# Altium schematic sheet PwrLMS7002M.SchDoc(PwrLMS7002M)
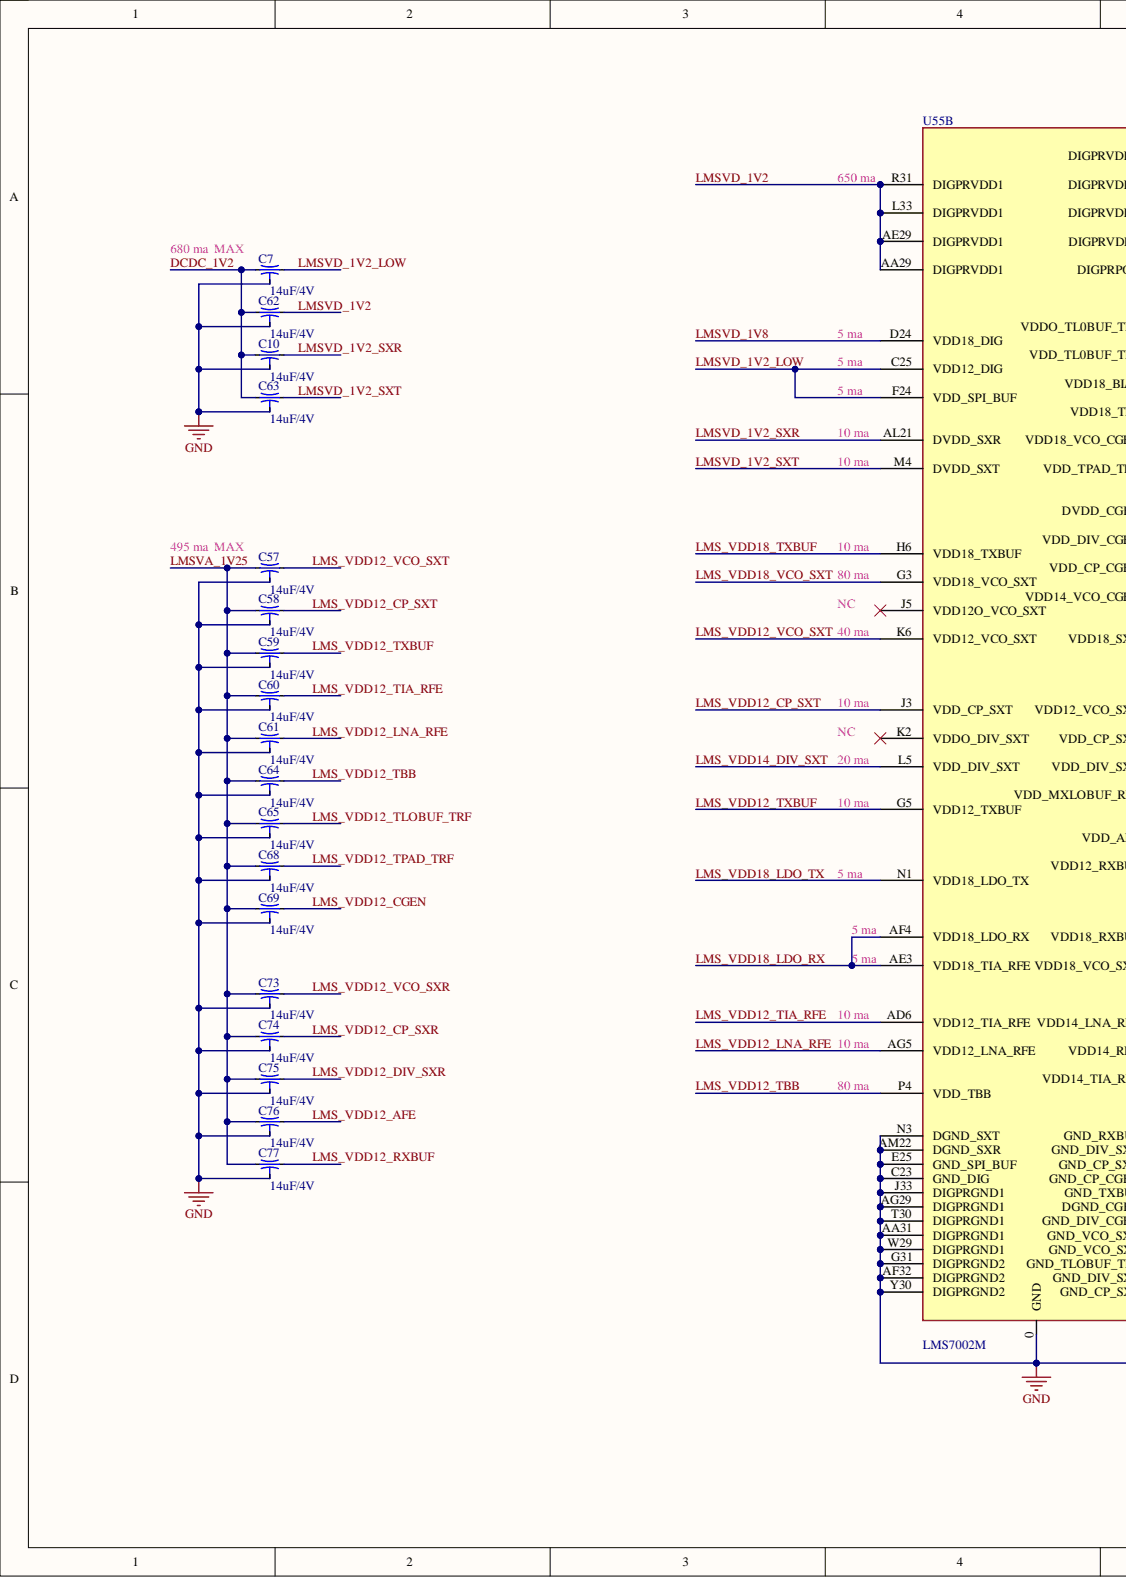
[diagram p0: PwrLMS7002M.SchDoc, left]
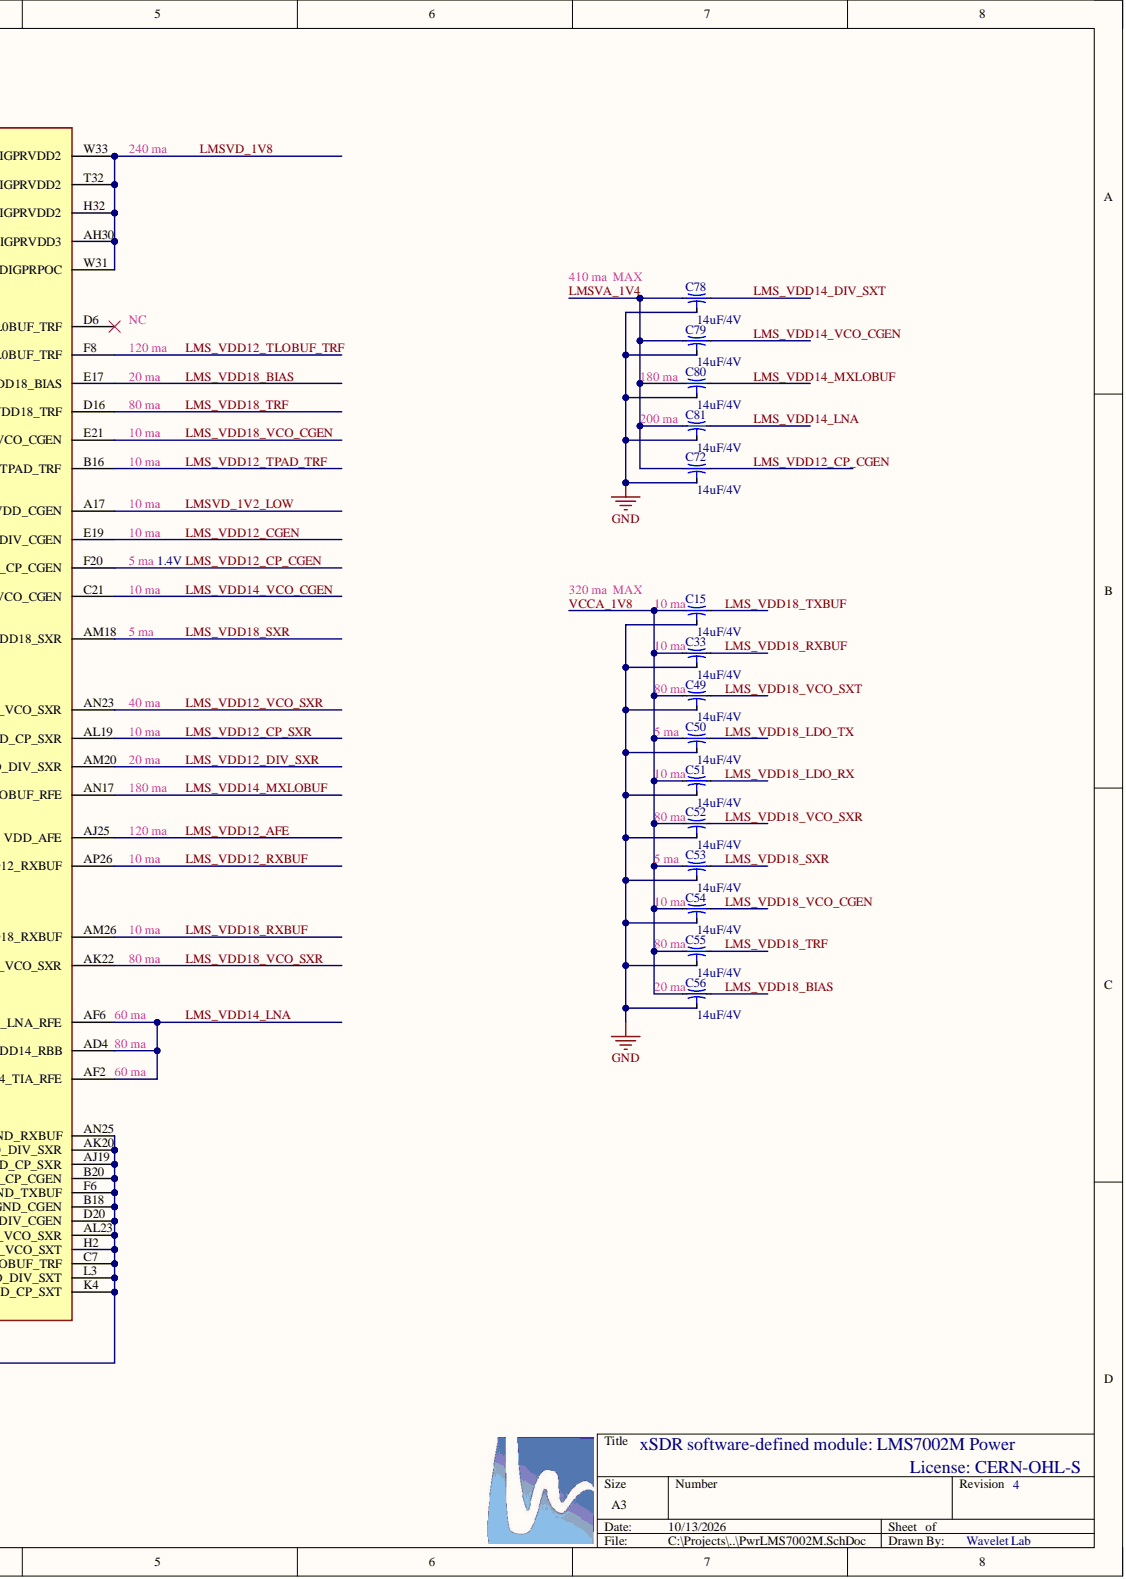
[diagram p1: PwrLMS7002M.SchDoc, right]

## Parts
C7  14uF/4V  [NFM15]
C10  14uF/4V  [NFM15]
C15  14uF/4V  [NFM15]
C33  14uF/4V  [NFM15]
C49  14uF/4V  [NFM15]
C50  14uF/4V  [NFM15]
C51  14uF/4V  [NFM15]
C52  14uF/4V  [NFM15]
C53  14uF/4V  [NFM15]
C54  14uF/4V  [NFM15]
C55  14uF/4V  [NFM15]
C56  14uF/4V  [NFM15]
C57  14uF/4V  [NFM15]
C58  14uF/4V  [NFM15]
C59  14uF/4V  [NFM15]
C60  14uF/4V  [NFM15]
C61  14uF/4V  [NFM15]
C62  14uF/4V  [NFM15]
C63  14uF/4V  [NFM15]
C64  14uF/4V  [NFM15]
C65  14uF/4V  [NFM15]
C68  14uF/4V  [NFM15]
C69  14uF/4V  [NFM15]
C72  14uF/4V  [NFM15]
C73  14uF/4V  [NFM15]
C74  14uF/4V  [NFM15]
C75  14uF/4V  [NFM15]
C76  14uF/4V  [NFM15]
C77  14uF/4V  [NFM15]
C78  14uF/4V  [NFM15]
C79  14uF/4V  [NFM15]
C80  14uF/4V  [NFM15]
C81  14uF/4V  [NFM15]
U55  LMS7002M  [AQFN260]  (part B)

## Nets
GND: C7.2 C10.2 C15.2 C33.2 C49.2 C50.2 C51.2 C52.2 C53.2 C54.2 C55.2 C56.2 C57.2 C58.2 C59.2 C60.2 C61.2 C62.2 C63.2 C64.2 C65.2 C68.2 C69.2 C72.2 C73.2 C74.2 C75.2 C76.2 C77.2 C78.2 C79.2 C80.2 C81.2 U55.0(GND) U55.AA31(DIGPRGND1) U55.AF32(DIGPRGND2) U55.AG29(DIGPRGND1) U55.AJ19(GND_CP_SXR) U55.AK20(GND_DIV_SXR) U55.AL23(GND_VCO_SXR) U55.AM22(DGND_SXR) U55.AN25(GND_RXBUF) U55.B18(DGND_CGEN) U55.B20(GND_CP_CGEN) U55.C7(GND_TLOBUF_TRF) U55.C23(GND_DIG) U55.D20(GND_DIV_CGEN) U55.E25(GND_SPI_BUF) U55.F6(GND_TXBUF) U55.G31(DIGPRGND2) U55.H2(GND_VCO_SXT) U55.J33(DIGPRGND1) U55.K4(GND_CP_SXT) U55.L3(GND_DIV_SXT) U55.N3(DGND_SXT) U55.T30(DIGPRGND1) U55.W29(DIGPRGND1) U55.Y30(DIGPRGND2)
DCDC_1V2: C7.1 C10.1 C62.1 C63.1
LMS_VDD12_AFE: C76.3 U55.AJ25(VDD_AFE)
LMS_VDD12_CGEN: C69.3 U55.E19(VDD_DIV_CGEN)
LMS_VDD12_CP_CGEN: C72.3 U55.F20(VDD_CP_CGEN)
LMS_VDD12_CP_SXR: C74.3 U55.AL19(VDD_CP_SXR)
LMS_VDD12_CP_SXT: C58.3 U55.J3(VDD_CP_SXT)
LMS_VDD12_DIV_SXR: C75.3 U55.AM20(VDD_DIV_SXR)
LMS_VDD12_LNA_RFE: C61.3 U55.AG5(VDD12_LNA_RFE)
LMS_VDD12_RXBUF: C77.3 U55.AP26(VDD12_RXBUF)
LMS_VDD12_TBB: C64.3 U55.P4(VDD_TBB)
LMS_VDD12_TIA_RFE: C60.3 U55.AD6(VDD12_TIA_RFE)
LMS_VDD12_TLOBUF_TRF: C65.3 U55.F8(VDD_TL0BUF_TRF)
LMS_VDD12_TPAD_TRF: C68.3 U55.B16(VDD_TPAD_TRF)
LMS_VDD12_TXBUF: C59.3 U55.G5(VDD12_TXBUF)
LMS_VDD12_VCO_SXR: C73.3 U55.AN23(VDD12_VCO_SXR)
LMS_VDD12_VCO_SXT: C57.3 U55.K6(VDD12_VCO_SXT)
LMS_VDD14_DIV_SXT: C78.3 U55.L5(VDD_DIV_SXT)
LMS_VDD14_LNA: C81.3 U55.AD4(VDD14_RBB) U55.AF2(VDD14_TIA_RFE) U55.AF6(VDD14_LNA_RFE)
LMS_VDD14_MXLOBUF: C80.3 U55.AN17(VDD_MXLOBUF_RFE)
LMS_VDD14_VCO_CGEN: C79.3 U55.C21(VDD14_VCO_CGEN)
LMS_VDD18_BIAS: C56.3 U55.E17(VDD18_BIAS)
LMS_VDD18_LDO_RX: C51.3 U55.AE3(VDD18_TIA_RFE) U55.AF4(VDD18_LDO_RX)
LMS_VDD18_LDO_TX: C50.3 U55.N1(VDD18_LDO_TX)
LMS_VDD18_RXBUF: C33.3 U55.AM26(VDD18_RXBUF)
LMS_VDD18_SXR: C53.3 U55.AM18(VDD18_SXR)
LMS_VDD18_TRF: C55.3 U55.D16(VDD18_TRF)
LMS_VDD18_TXBUF: C15.3 U55.H6(VDD18_TXBUF)
LMS_VDD18_VCO_CGEN: C54.3 U55.E21(VDD18_VCO_CGEN)
LMS_VDD18_VCO_SXR: C52.3 U55.AK22(VDD18_VCO_SXR)
LMS_VDD18_VCO_SXT: C49.3 U55.G3(VDD18_VCO_SXT)
LMSVA_1V4: C72.1 C78.1 C79.1 C80.1 C81.1
LMSVA_1V25: C57.1 C58.1 C59.1 C60.1 C61.1 C64.1 C65.1 C68.1 C69.1 C73.1 C74.1 C75.1 C76.1 C77.1
LMSVD_1V2: C62.3 U55.AA29(DIGPRVDD1) U55.AE29(DIGPRVDD1) U55.L33(DIGPRVDD1) U55.R31(DIGPRVDD1)
LMSVD_1V2_LOW: C7.3 U55.A17(DVDD_CGEN) U55.C25(VDD12_DIG) U55.F24(VDD_SPI_BUF)
LMSVD_1V2_SXR: C10.3 U55.AL21(DVDD_SXR)
LMSVD_1V2_SXT: C63.3 U55.M4(DVDD_SXT)
LMSVD_1V8: U55.AH30(DIGPRVDD3) U55.D24(VDD18_DIG) U55.H32(DIGPRVDD2) U55.T32(DIGPRVDD2) U55.W31(DIGPRPOC) U55.W33(DIGPRVDD2)
VCCA_1V8: C15.1 C33.1 C49.1 C50.1 C51.1 C52.1 C53.1 C54.1 C55.1 C56.1

Not connected: U55 D6(VDDO_TL0BUF_TRF) J5(VDD12O_VCO_SXT) K2(VDDO_DIV_SXT)

## Library data of the parts
C7 C10 C15 C33 C49-C65 C68 C69 C72-C81 = NFM15: Filter EMI 0402 Feedthrough; Manufacturer: MuRata; Name: NFM15PC146R0G3D; Tolerance: 20%; U/P/TKE: 4V/2A/30mO/-55+85℃; Component Kind: Standard; Link: https://octopart.com/nfm15pc146r0g3d-murata-94414995; PDF: https://datasheet.octopart.com/NFM15PC146R0G3D-Murata-datasheet-138760002.pdf; Value: 14uF/4V; Library Name: USDR.IntLib
U55 = LMS7002M: FPRF MIMO Transceiver IC; Manufacturer: Lime Microsystems; Misc: Multi-band Multi-standard  Transceiver with Digital Interface; Component Kind: Standard; Name: LMS7002M; Library Name: USDR.IntLib
